# Altium schematic sheet Connectors.SchDoc
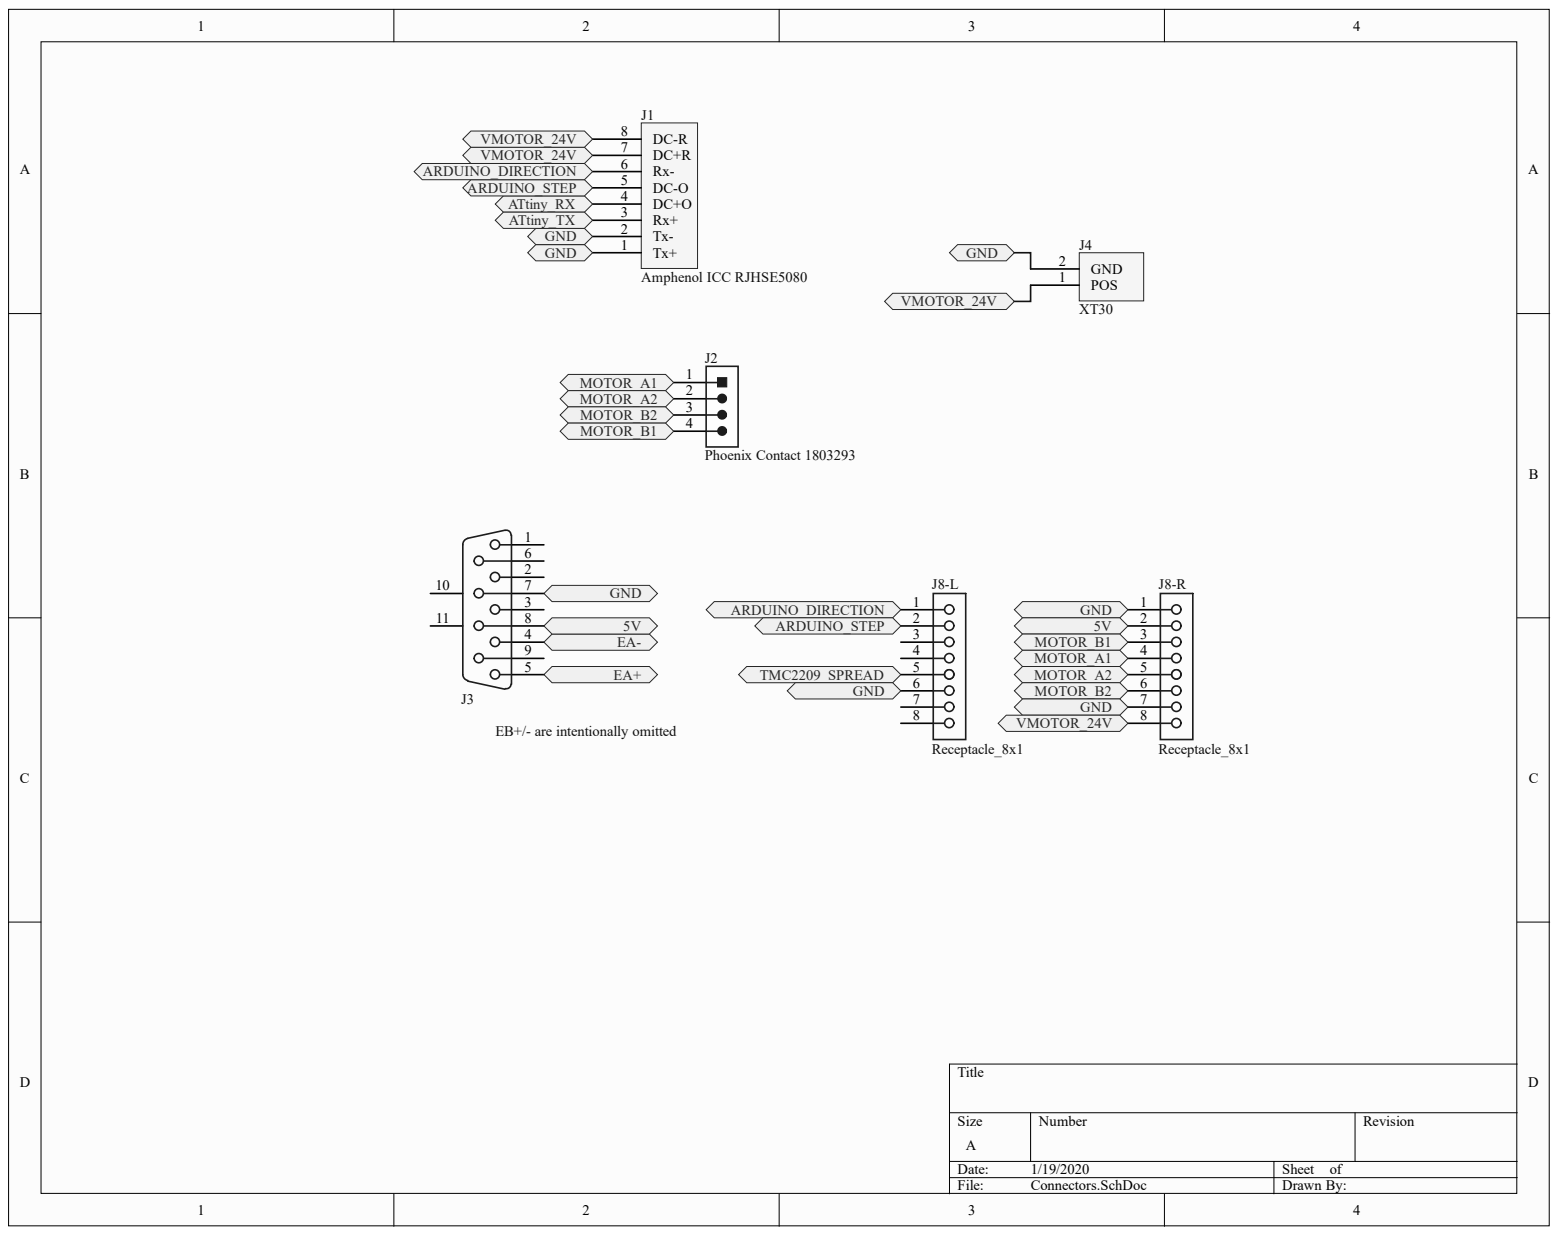
[diagram p0: Connectors.SchDoc]

## Parts
J1  Amphenol ICC RJHSE5080  [PCB-qwl9qjksk46hanrttlea-1]
J2  Phoenix Contact 1803293  [PCB-5cazq4m146w1qodtmxk1-1]
J3  TE Connectivity / AMP 5747844-4  [PCB-0njr3notfioafbnn7qbr-1]  (value not drawn)
J4  XT30  [PCB-qcnpj6p0hbzpl0cja5hs-1]
J8-L  Receptacle_8x1  [PCB-rk0xvjxtj9hjg7xlxwg2-1]
J8-R  Receptacle_8x1  [PCB-rk0xvjxtj9hjg7xlxwg2-1]

## Ports
5V, ARDUINO_DIRECTION, ARDUINO_STEP, ATTINY_RX, ATTINY_TX, EA+, EA-, GND, MOTOR_A1, MOTOR_A2, MOTOR_B1, MOTOR_B2, TMC2209_SPREAD, VMOTOR_24V

## Nets
ATTINY_RX: J1.4(DC+O) ; port ATTINY_RX
ATTINY_TX: J1.3(Rx+) ; port ATTINY_TX
5V: J3.8 J8-R.2 ; port 5V
ARDUINO_DIRECTION: J1.6(Rx-) J8-L.1 ; port ARDUINO_DIRECTION
ARDUINO_STEP: J1.5(DC-O) J8-L.2 ; port ARDUINO_STEP
EA+: J3.5 ; port EA+
EA-: J3.4 ; port EA-
GND: J1.1(Tx+) J1.2(Tx-) J3.7 J4.2 J8-L.6 J8-R.1 J8-R.7 ; port GND
MOTOR_A1: J2.1 J8-R.4 ; port MOTOR_A1
MOTOR_A2: J2.2 J8-R.5 ; port MOTOR_A2
MOTOR_B1: J2.4 J8-R.3 ; port MOTOR_B1
MOTOR_B2: J2.3 J8-R.6 ; port MOTOR_B2
TMC2209_SPREAD: J8-L.5 ; port TMC2209_SPREAD
VMOTOR_24V: J1.7(DC+R) J1.8(DC-R) J4.1 J8-R.8 ; port VMOTOR_24V

Not connected: J3 1 2 3 6 9 10 11; J8-L 3 4 7 8

## Library data of the parts
J1 = CMP-cf9c03f44717105b-1: Connector; Modular Jack; 8; Phosphor Bronze; Gold Over Nickel; 0.62 in.; Lead-Free Status: Lead Free; Number of Contacts: 8; Shielding: No; Voltage Rating (DC): 125 V; Color: Black; Component Kind: Standard; Contact Material: Phosphor Bronze; Contact Plating: Gold over Nickel, Gold; Library Name: CommunityVault; Manufacturer Part Number: RJHSE5080; Number of Positions: 8; OctopartID: cf9c03f44717105b; Octopart URL: https://octopart.com/rjhse5080-amphenol+icc-90353133; Orientation: Right Angle; Packaging: Tray; REACH SVHC Compliance: No SVHC; RoHS: Compliant; Size-Height: 13.2 mm; Size-Length: 15.7 mm
J2 = CMP-724734-4: Wire-To-Board Connector, Right Angle, MC Series, Through Hole, Header, 4, 3.81 mm; Connector type: MC 1.5 / 4-G-3.81; Current Rating: 8A; Lead-Free Status: Lead Free; Mounting Angle: 90.0 °; Number of Positions: 4; Pin Pitch: 0.00381 m; Voltage Rating: 160V; Color: Green; Component Kind: Standard; Flammability Rating: UL 94 V-0; Housing Color: Green; Housing Material: Plastic (PBT); Library Name: CommunityVault; Manufacturer Part Number: 1803293; Mounting Style: PCB, Through Hole, Solder; Number of Rows: 1; OctopartID: 0e9ac7905cd5d15d; Octopart URL: http://octopart.com/1803293-phoenix+contact-30505; RoHS: Compliant; Size-Height: 0.0111 m; Size-Length: 0.01663 m; Size-Width: 0.0187 m
J3 = CMP-caba01e9819a157d-5: Connector D-Subminiature Receptacle 9 Position 2.74mm Solder Right Angle Through Hole 9 Terminal 1 Port Tray; Component Kind: Standard; Library Name: CommunityVault
J4 = CMP-9e1652bfce1041d1-4: High Quality Gold Plated XT30 Male Female Bullet Connector; Manufacturer Part Number: FIT0586; Component Kind: Standard; Library Name: FavoritesLibrary; OctopartID: 9e1652bfce1041d1; Octopart URL: https://octopart.com/fit0586-dfrobot-94598496
J8-L J8-R = CMP-674378-7: Female, 8C, Straight, .100"CC; 3.20mm Tail, No Mt. 100u" Tin; PBT, Phos Bronze, 105 C; Manufacturer Part Number: PPTC081LFBN-RC; Lead-Free Status: Lead Free; Number of Positions: 8; Pin Pitch: 2.54 mm; Color: Black; Component Kind: Standard; Contact Material: Phosphor Bronze; Contact Plating: Tin; Gender: Female; Housing Material: Plastic; Library Name: CommunityVault; Mounting Style: Through Hole; Number of Rows: 1; Octopart URL: https://octopart.com/pptc081lfbn-rc-sullins-271024; Operating Temperature: 125 °C (max); Packaging: Bulk; RoHS: Compliant; Size-Height: 8.50 mm; Size-Width: 2.50 mm
